# Altium schematic sheet CAR_FAN_RELAY_1.SchDoc(CAR_FAN_RELAY_1)
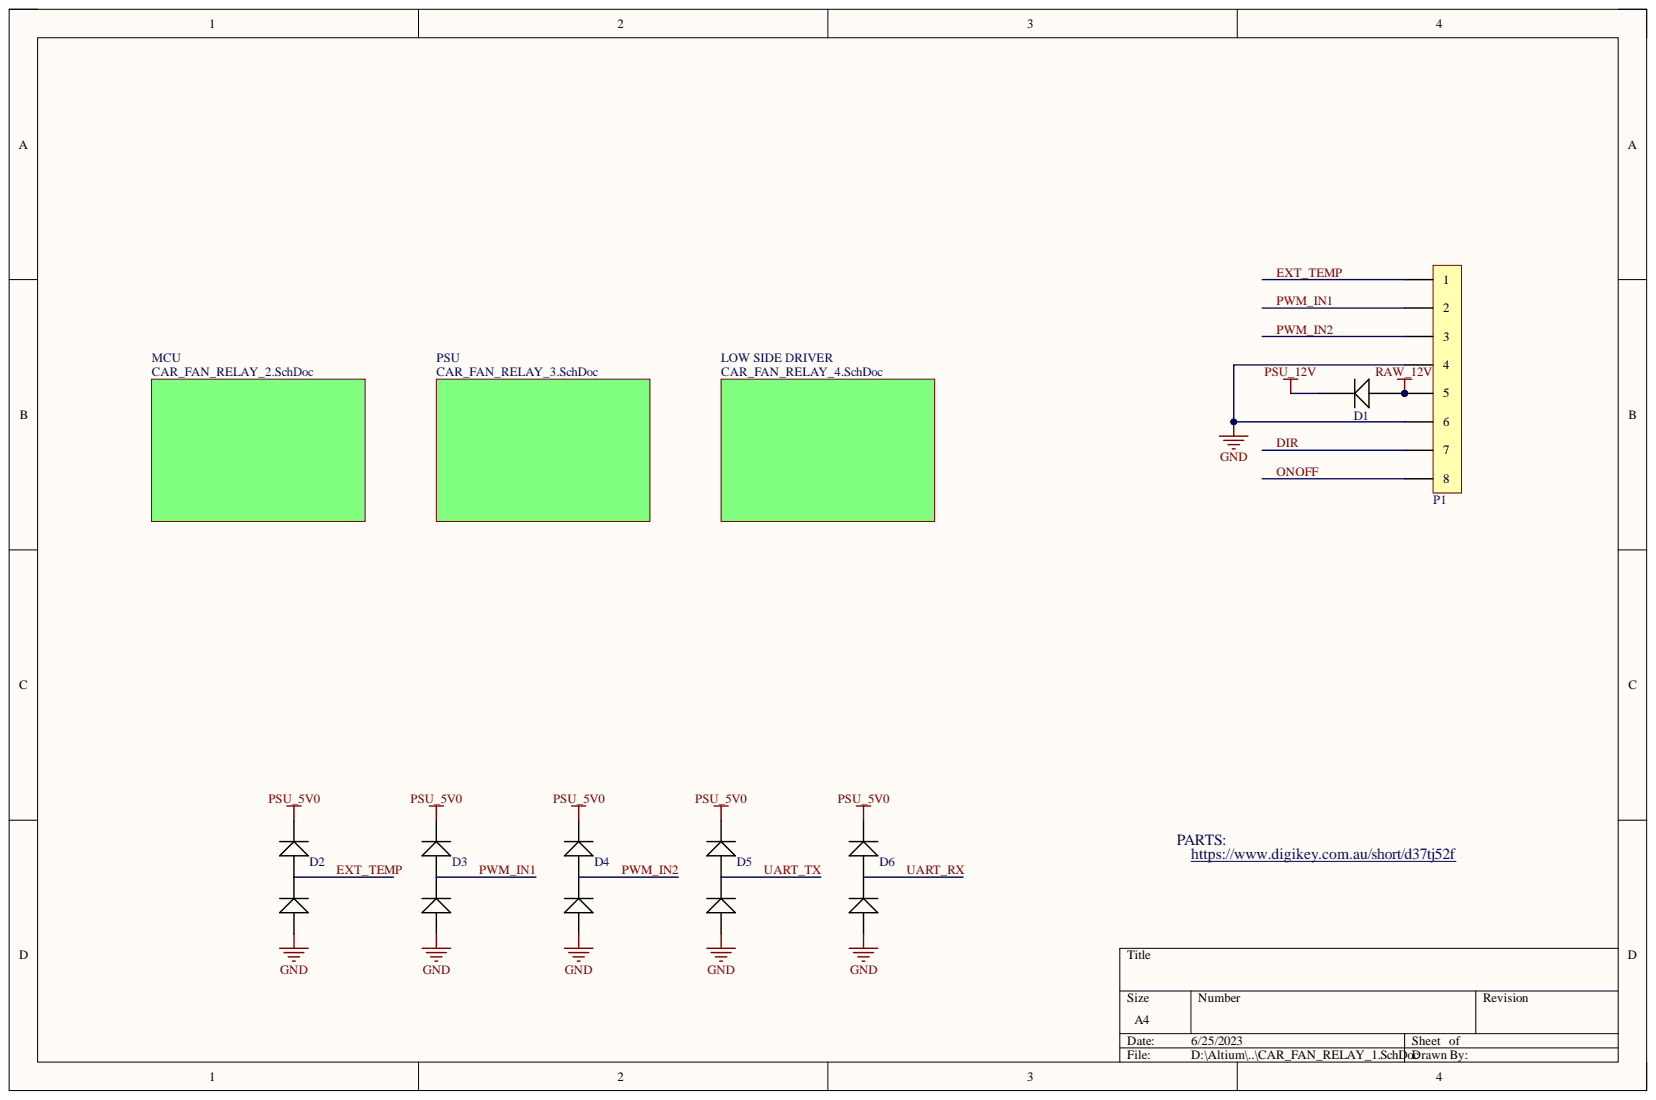
[diagram p0: CAR_FAN_RELAY_1.SchDoc]

## Parts
D1  SK510B-LTP  [SMB]  (value not drawn)
D2  Dual Diode  [SOT23]  (value not drawn)
D3  Dual Diode  [SOT23]  (value not drawn)
D4  Dual Diode  [SOT23]  (value not drawn)
D5  Dual Diode  [SOT23]  (value not drawn)
D6  Dual Diode  [SOT23]  (value not drawn)
P1  SCREW TERMINAL  [TERMINAL BLOCK]  (value not drawn)

## Nets
GND: D2.1 D3.1 D4.1 D5.1 D6.1 P1.4 P1.6
PSU_5V0: D2.2 D3.2 D4.2 D5.2 D6.2
PSU_12V: D1.K
RAW_12V: D1.A P1.5
DIR: P1.7
EXT_TEMP: D2.3 P1.1
ONOFF: P1.8
PWM_IN1: D3.3 P1.2
PWM_IN2: D4.3 P1.3
UART_RX: D6.3
UART_TX: D5.3

## Library data of the parts
D1 = DIODE: 5A Shottky rectifier diode; Component Kind: Standard; Library Name: CAR_FAN_RELAY.SchLib
D2-D6 = DUAL_DIODE: Component Kind: Standard; Description: Dual Diode; Library Name: CAR_FAN_RELAY.SchLib
P1 = TERMINAL_BLOCK_8: Component Kind: Standard; Library Name: CAR_FAN_RELAY.SchLib
